# Altium schematic sheet power.SchDoc
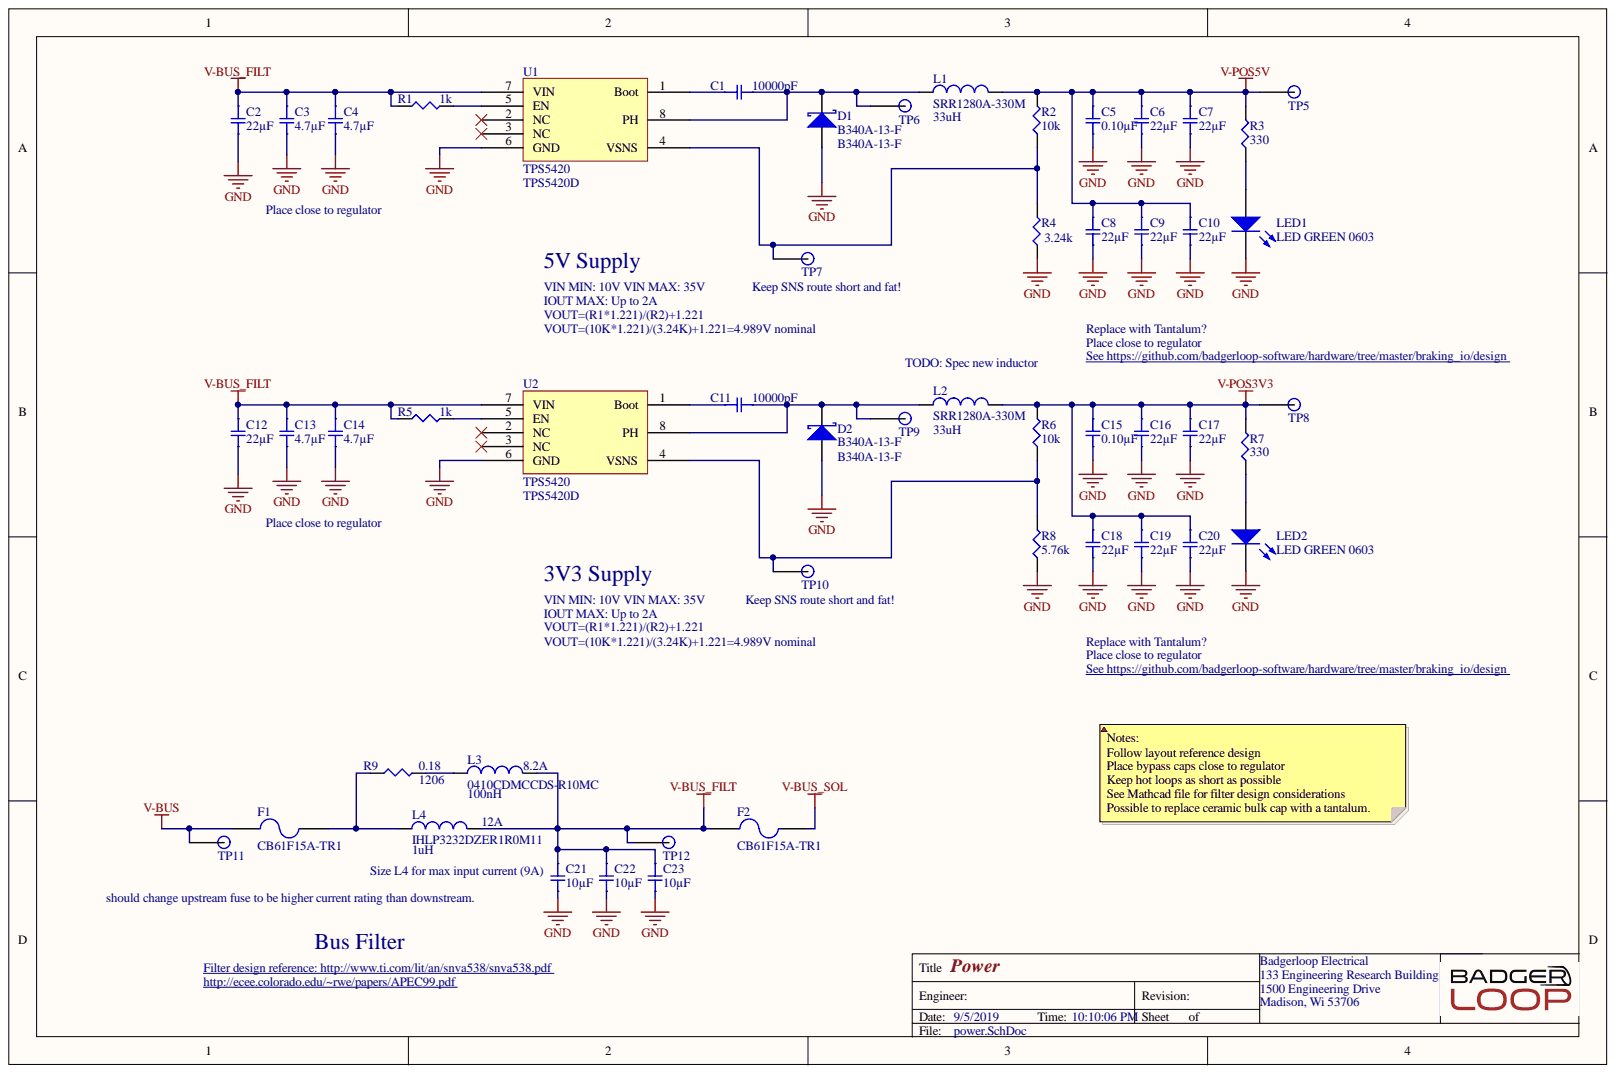
[diagram p0: power.SchDoc]

## Parts
C1  10000pF  [SMD-0805C]
C2  22µF  [SMD-1206C]
C3  4.7µF  [SMD-1206C]
C4  4.7µF  [SMD-1206C]
C5  0.10µF  [SMD-0805C]
C6  22µF  [SMD-1206C]
C7  22µF  [SMD-1206C]
C8  22µF  [SMD-1206C]
C9  22µF  [SMD-1206C]
C10  22µF  [SMD-1206C]
C11  10000pF  [SMD-0805C]
C12  22µF  [SMD-1206C]
C13  4.7µF  [SMD-1206C]
C14  4.7µF  [SMD-1206C]
C15  0.10µF  [SMD-0805C]
C16  22µF  [SMD-1206C]
C17  22µF  [SMD-1206C]
C18  22µF  [SMD-1206C]
C19  22µF  [SMD-1206C]
C20  22µF  [SMD-1206C]
C21  10µF  [SMD-1206C]
C22  10µF  [SMD-1206C]
C23  10µF  [SMD-1206C]
D1  B340A-13-F  [DIOM4326X20N]
D2  B340A-13-F  [DIOM4326X20N]
F1  CB61F15A-TR1  [RESC6132X26N]
F2  CB61F15A-TR1  [RESC6132X26N]
L1  SRR1280A-330M  [INDM120125X75N]
L2  SRR1280A-330M  [INDM120125X75N]
L3  0410CDMCCDS-R10MC  Inductance: 100nH  Saturation Current: 8.2A  [INDM4442X20N]
L4  IHLP3232DZER1R0M11  Inductance: 1uH  Saturation Current: 12A  [INDM8682X40N]
LED1  LED GREEN 0603  [LED 0603 GREEN]
LED2  LED GREEN 0603  [LED 0603 GREEN]
R1  1k  [SMD-0805-RES]
R2  10k  [SMD-0805-RES]
R3  330  [SMD-0805-RES]
R4  3.24k  [SMD-0805-RES]
R5  1k  [SMD-0805-RES]
R6  10k  [SMD-0805-RES]
R7  330  [SMD-0805-RES]
R8  5.76k  [SMD-0805-RES]
R9  0.18  Supplier Device Package: 1206  [SMD-1206-RES]
TP5  TP  [Test Point]
TP6  TP  [Test Point]
TP7  TP  [Test Point]
TP8  TP  [Test Point]
TP9  TP  [Test Point]
TP10  TP  [Test Point]
TP11  TP  [Test Point]
TP12  TP  [Test Point]
U1  TPS5420  Manufacturer Part Number: TPS5420D  [SOP127P600X175-8N]
U2  TPS5420  Manufacturer Part Number: TPS5420D  [SOP127P600X175-8N]

## Nets
GND: C2.2 C3.2 C4.2 C5.2 C6.2 C7.2 C8.2 C9.2 C10.2 C12.2 C13.2 C14.2 C15.2 C16.2 C17.2 C18.2 C19.2 C20.2 C21.2 C22.2 C23.2 D1.1 D2.1 LED1.2 LED2.2 R4.1 R8.1 U1.6(GND) U2.6(GND)
V-BUS: F1.1 TP11.1
V-BUS_FILT: C2.1 C3.1 C4.1 C12.1 C13.1 C14.1 C21.1 C22.1 C23.1 F2.1 L3.2 L4.2 R1.1 R5.1 TP12.1 U1.7(VIN) U2.7(VIN)
V-BUS_SOL: F2.2
V-POS3V3: C15.1 C16.1 C17.1 C18.1 C19.1 C20.1 L2.2 R6.2 R7.2 TP8.1
V-POS5V: C5.1 C6.1 C7.1 C8.1 C9.1 C10.1 L1.2 R2.2 R3.2 TP5.1
NetC1_1: C1.1 U1.1(Boot)
NetC1_2: C1.2 D1.2 L1.1 TP6.1 U1.8(PH)
NetC11_1: C11.1 U2.1(Boot)
NetC11_2: C11.2 D2.2 L2.1 TP9.1 U2.8(PH)
NetF1_2: F1.2 L4.1 R9.1
NetL3_1: L3.1 R9.2
NetLED1_1: LED1.1 R3.1
NetLED2_1: LED2.1 R7.1
NetR1_2: R1.2 U1.5(EN)
NetR2_1: R2.1 R4.2 TP7.1 U1.4(VSNS)
NetR5_2: R5.2 U2.5(EN)
NetR6_1: R6.1 R8.2 TP10.1 U2.4(VSNS)

Not connected: U1 2(NC) 3(NC); U2 2(NC) 3(NC)

## Library data of the parts
C1 C11 = GC321AD72E103KX01D: CAP CER 10000PF 250V X7T 0805; Manufacturer: Murata Electronics North America; @ qty: 0; Minimum Quantity: 1; Tolerance: ±10%; Unit Price (USD): 0.3; Applications: Automotive; Component Kind: Standard; Datasheets: http://search.murata.co.jp/Ceramy/image/img/A01X/G101/ENG/GC321AD72E103KX01-01.pdf; Digi-Key Part Number: 490-13986-1-ND; Factory Stock: 0; Features: -; Height - Seated (Max): -; Image: //media.digikey.com/Renders/Murata%20Renders/0805(GC3)200x125.jpg; Lead Spacing: -; Lead Style: -; Library Name: capacitors.SchLib; Mounting Type: Surface Mount, MLCC; Operating Temperature: -55°C ~ 125°C; Package / Case: 0805 (2012 Metric); Packaging: Cut Tape (CT); Part Number: GC321AD72E103KX01D; Part Status: Active; Quantity Available: 4000; Ratings: AEC-Q200; Series: GC3; Size / Dimension: 0.079" L x 0.049" W (2.00mm x 1.25mm); Temperature Coefficient: X7T; Thickness (Max): 0.039" (1.00mm); Value: 10000pF; Voltage: 250V
C2 C6-C10 C12 C16-C20 = GRT31CR61E226ME01L: CAP CER 22UF 25V X5R 1206; Manufacturer: Murata Electronics North America; @ qty: 0; Minimum Quantity: 1; Tolerance: ±20%; Unit Price (USD): 0.52; Applications: Automotive; Component Kind: Standard; Datasheets: http://search.murata.co.jp/Ceramy/image/img/A01X/G101/ENG/GRT31CR61E226ME01-01.pdf; Digi-Key Part Number: 490-12451-1-ND; Factory Stock: 0; Features: -; Height - Seated (Max): -; Image: //media.digikey.com/Renders/Murata%20Renders/GR331BD72E473KW01L.jpg; Lead Spacing: -; Lead Style: -; Library Name: capacitors.SchLib; Mounting Type: Surface Mount, MLCC; Operating Temperature: -55°C ~ 85°C; Package / Case: 1206 (3216 Metric); Packaging: Cut Tape (CT); Part Number: GRT31CR61E226ME01L; Part Status: Active; Quantity Available: 4719; Ratings: AEC-Q200; Series: GRT; Size / Dimension: 0.126" L x 0.063" W (3.20mm x 1.60mm); Temperature Coefficient: X5R; Thickness (Max): 0.071" (1.80mm); Value: 22µF; Voltage: 25V
C3 C4 C13 C14 = GCM31CC71H475KA03L: CAP CER 4.7UF 50V X7S 1206; Manufacturer: Murata Electronics North America; @ qty: 0; Minimum Quantity: 1; Tolerance: ±10%; Unit Price (USD): 0.57; Applications: Automotive; Component Kind: Standard; Datasheets: http://www.murata.com/~/media/webrenewal/support/library/catalog/products/capacitor/mlcc/c03e.ashx; Digi-Key Part Number: 490-11167-1-ND; Factory Stock: 0; Features: -; Height - Seated (Max): -; Image: //media.digikey.com/Renders/Murata%20Renders/GR331BD72E473KW01L.jpg; Lead Spacing: -; Lead Style: -; Library Name: capacitors.SchLib; Mounting Type: Surface Mount, MLCC; Operating Temperature: -55°C ~ 125°C; Package / Case: 1206 (3216 Metric); Packaging: Cut Tape (CT); Part Number: GCM31CC71H475KA03L; Part Status: Active; Quantity Available: 11700; Ratings: AEC-Q200; Series: GCM; Size / Dimension: 0.126" L x 0.063" W (3.20mm x 1.60mm); Temperature Coefficient: X7S; Thickness (Max): 0.071" (1.80mm); Value: 4.7µF; Voltage: 50V
C5 C15 = GRM21B7U1A104JA01L: CAP CER 0.1UF 10V U2J 0805; Manufacturer: Murata Electronics North America; @ qty: 0; Minimum Quantity: 1; Tolerance: ±5%; Unit Price (USD): 0.4; Applications: General Purpose; Component Kind: Standard; Datasheets: http://www.murata.com/~/media/webrenewal/support/library/catalog/products/capacitor/mlcc/c02e.pdf; Digi-Key Part Number: 490-11592-1-ND; Factory Stock: 0; Features: -; Height - Seated (Max): -; Image: //media.digikey.com/Renders/Murata%20Renders/0805%20(2012%20Metric)%20.85mm.jpg; Lead Spacing: -; Lead Style: -; Library Name: capacitors.SchLib; Mounting Type: Surface Mount, MLCC; Operating Temperature: -55°C ~ 125°C; Package / Case: 0805 (2012 Metric); Packaging: Cut Tape (CT); Part Number: GRM21B7U1A104JA01L; Part Status: Active; Quantity Available: 3390; Ratings: -; Series: GRM; Size / Dimension: 0.079" L x 0.049" W (2.00mm x 1.25mm); Temperature Coefficient: U2J; Thickness (Max): 0.053" (1.35mm); Value: 0.10µF; Voltage: 10V
C21-C23 = GRT31CR61H106ME01L: CAP CER 10UF 50V X5R 1206; Manufacturer: Murata Electronics North America; @ qty: 0; Minimum Quantity: 1; Tolerance: ±20%; Unit Price (USD): 0.42; Applications: Automotive; Component Kind: Standard; Datasheets: http://search.murata.co.jp/Ceramy/image/img/A01X/G101/ENG/GRT31CR61H106ME01-01.pdf; Digi-Key Part Number: 490-12457-1-ND; Factory Stock: 0; Features: -; Height - Seated (Max): -; Image: //media.digikey.com/Renders/Murata%20Renders/GR331BD72E473KW01L.jpg; Lead Spacing: -; Lead Style: -; Library Name: capacitors.SchLib; Mounting Type: Surface Mount, MLCC; Operating Temperature: -55°C ~ 85°C; Package / Case: 1206 (3216 Metric); Packaging: Cut Tape (CT); Part Number: GRT31CR61H106ME01L; Part Status: Active; Quantity Available: 3394; Ratings: AEC-Q200; Series: GRT; Size / Dimension: 0.126" L x 0.063" W (3.20mm x 1.60mm); Temperature Coefficient: X5R; Thickness (Max): 0.071" (1.80mm); Value: 10µF; Voltage: 50V
D1 D2 = B340A-13-F: DIODE SCHOTTKY 40V 3A SMA; Manufacturer: Diodes; Capacitance: 250pF; Element Configuration: Single; Forward Voltage: 500mV; Max Forward Surge Current (Ifsm): 80A; Peak Reverse Current: 500uA; Polarity: Standard; Average Rectified Current: 3A; Case/Package: SMA; Component Kind: Standard; ComponentLink1Description: https://datasheet.ciiva.com/26949/getdatasheetpartid-143394-26949352.pdf?src-supplier=Verical; ComponentLink1URL: https://datasheet.ciiva.com/26949/getdatasheetpartid-143394-26949352.pdf?src-supplier=Verical; ComponentLink2Description: https://datasheet.ciiva.com/4245/861ds30891-4245763.pdf?src-supplier=Arrow; ComponentLink2URL: https://datasheet.ciiva.com/4245/861ds30891-4245763.pdf?src-supplier=Arrow; ComponentLink3Description: https://datasheet.ciiva.com/1177/ds30891-1177476.pdf?src-supplier=Element14; ComponentLink3URL: https://datasheet.ciiva.com/1177/ds30891-1177476.pdf?src-supplier=Element14; ComponentLink4Description: https://datasheet.ciiva.com/913/ds30891-913638.pdf?src-supplier=Digi-Key; ComponentLink4URL: https://datasheet.ciiva.com/913/ds30891-913638.pdf?src-supplier=Digi-Key; ComponentLink5Description: https://datasheet.ciiva.com/46393/1911813-46393773.pdf?src-supplier=Element14; ComponentLink5URL: https://datasheet.ciiva.com/46393/1911813-46393773.pdf?src-supplier=Element14; ComponentLink6Description: https://datasheet.ciiva.com/1240/ds30891-1240228.pdf?src-supplier=Newark; ComponentLink6URL: https://datasheet.ciiva.com/1240/ds30891-1240228.pdf?src-supplier=Newark; ComponentLink7Description: https://datasheet.ciiva.com/913/ds30891-913637.pdf?src-supplier=Digi-Key; ComponentLink7URL: https://datasheet.ciiva.com/913/ds30891-913637.pdf?src-supplier=Digi-Key; ComponentLink8Description: https://datasheet.ciiva.com/913/ds30891-913639.pdf?src-supplier=Digi-Key; ComponentLink8URL: https://datasheet.ciiva.com/913/ds30891-913639.pdf?src-supplier=Digi-Key; Contact Plating: Tin,Matte; Current: 3A; Current Rating: 3A; Forward Current: 3A; Height: 2.3mm; Lead Free: Lead Free; Length: 4.6mm; Library Name: misc.SchLib; Manufacturer Part Number: B340A-13-F; Max Operating Temperature: 125°C; Max Repetitive Reverse Voltage (Vrrm): 40V; Max Reverse Voltage (DC): 40V; Min Operating Temperature: -55°C; Mount: Surface Mount; Number of Pins: 2; Output Current: 3A; Package Quantity: 5000; Packaging: Tape and Reel; Peak Non-Repetitive Surge Current: 80A; Pricing 1: 1=0.42000, 10=0.33900, 100=0.23070, 500=0.17304, 1000=0.12978 (USD); Radiation Hardening: No; REACH SVHC: No SVHC; RoHS: Yes; Stock 1: 438328; Supplier 1: Digi-Key; Supplier Part Number 1: B340A-FDICT-ND; Termination: SMD/SMT; Voltage: 40V; Voltage Rating (DC): 40V; Weight: 0.002258oz; Width: 2.92mm
F1 F2 = CB61F15A-TR1: Manufacturer: Eaton; Approvals: cULus,PSE; Current Rating: 15A; DC Cold Resistance: 4mR; Fuse Type: Board Mount (Cartridge Style Excluded); Voltage Rating: 125V; Case/Package: SMD/SMT; Component Kind: Standard; ComponentLink1Description: http://components.arrow.com/part/dataview/0323_05918082?src-supplier=Arrow+Europe; ComponentLink1URL: http://components.arrow.com/part/dataview/0323_05918082?src-supplier=Arrow+Europe; ComponentLink2Description: http://www.cooperindustries.com/content/dam/public/bussmann/Electronics/Resources/product-datasheets/bus-elx-ds-4377-cb61f.pdf?src-supplier=Avnet+Asia; ComponentLink2URL: http://www.cooperindustries.com/content/dam/public/bussmann/Electronics/Resources/product-datasheets/bus-elx-ds-4377-cb61f.pdf?src-supplier=Avnet+Asia; ComponentLink3Description: http://www.cooperindustries.com/content/dam/public/bussmann/Electronics/Resources/product-datasheets/bus-elx-ds-4377-cb61f.pdf?src-supplier=Avnet; ComponentLink3URL: http://www.cooperindustries.com/content/dam/public/bussmann/Electronics/Resources/product-datasheets/bus-elx-ds-4377-cb61f.pdf?src-supplier=Avnet; ComponentLink4Description: http://www.cooperindustries.com/content/dam/public/bussmann/Electronics/Resources/product-datasheets/bus-elx-ds-4377-cb61f.pdf?src-supplier=Digi-Key; ComponentLink4URL: http://www.cooperindustries.com/content/dam/public/bussmann/Electronics/Resources/product-datasheets/bus-elx-ds-4377-cb61f.pdf?src-supplier=Digi-Key; Depth: 2.57mm; Height: 0.101inch; Lead Free: Lead Free; Length: 0.24inch; Library Name: misc.SchLib; Manufacturer Part Number: CB61F15A-TR1; Mount: Surface Mount; Packaging: Tape and Reel; Pricing 1: 1=1.15000, 5=1.11800, 10=1.04600, 50=0.86600, 100=0.72160, 250=0.70356, 500=0.59532 (USD); RoHS: Yes; Stock 1: 11809; Supplier 1: Digi-Key; Supplier Part Number 1: 283-4104-1-ND; Voltage Rating (AC): 125V; Voltage Rating (DC): 125V; Width: 0.101inch
L1 L2 = SRR1280A-330M: Inductor Power Shielded Wirewound 33uH 20% 1KHz 28Q-Factor Ferrite 3.5A 57mOhm DCR Automotive T/R; Manufacturer: Bourns; DC Resistance (DCR): 57mR; Saturation Current: 3.3A; Self Resonant Frequency: 9.5MHz; Case/Package: Nonstandard; Component Kind: Standard; ComponentLink1Description: https://datasheet.ciiva.com/41859/srr1280a-41859670.pdf?src-supplier=Digi-Key; ComponentLink1URL: https://datasheet.ciiva.com/41859/srr1280a-41859670.pdf?src-supplier=Digi-Key; ComponentLink2Description: https://datasheet.ciiva.com/48684/srr1280a-48684991.pdf?src-supplier=Avnet; ComponentLink2URL: https://datasheet.ciiva.com/48684/srr1280a-48684991.pdf?src-supplier=Avnet; Core Material: Ferrite; Current Rating: 3.5A; Depth: 12.8mm; Height: 7.5mm; Height - Seated (Max): 0.315inch; Inductance: 33uH; Inductance Tolerance: 20%; Length: 0.492inch; Library Name: misc.SchLib; Manufacturer Part Number: SRR1280A-330M; Max DC Current: 3.5A; Max Operating Temperature: 125°C; Military Standard: Not; Min Operating Temperature: -40°C; Mount: Surface Mount; Package Quantity: 400; Packaging: Cut Tape; Pricing 1: 2400=0.54000, 4000=0.48600, 6000=0.46800, 10000=0.45800 (USD); Ratings: AEC-Q200; REACH SVHC: No SVHC; RMS Current (Irms): 3.5A; RoHS: Yes; Shielding: Shielded; Stock 1: 0; Supplier 1: Newark; Supplier Part Number 1: 06X7909; Test Frequency: 1kHz; Tolerance: 20%; Width: 0.492inch
L3 = 0410CDMCCDS-R10MC: Manufacturer: Sumida; Component Kind: Standard; Library Name: inductor.SchLib; Manufacturer Part Number: 0410CDMCCDS-R10MC; Pricing 1: 1=0.93000, 10=0.81700, 100=0.58360, 500=0.49024, 1000=0.39687 (USD); RoHS: No; Stock 1: 5994; Supplier 1: Digi-Key; Supplier Part Number 1: 308-2523-1-ND
L4 = IHLP3232DZER1R0M11: Fixed Inductors 1uH 20% Low Profile; Manufacturer: Vishay Dale; Core Material: Metal,Composite,Ferrite; Current Rating: 18.2A; DC Resistance (DCR): 4.63mR; Self Resonant Frequency: 49MHz; Shielding: Shielded; Test Frequency: 100kHz; Case/Package: 3232; Component Kind: Standard; ComponentLink1Description: https://datasheet.ciiva.com/6692/ihlp-3232dz-11-6692199.pdf?src-supplier=Digi-Key; ComponentLink1URL: https://datasheet.ciiva.com/6692/ihlp-3232dz-11-6692199.pdf?src-supplier=Digi-Key; ComponentLink2Description: https://datasheet.ciiva.com/6692/ihlp-3232dz-11-6692200.pdf?src-supplier=Digi-Key; ComponentLink2URL: https://datasheet.ciiva.com/6692/ihlp-3232dz-11-6692200.pdf?src-supplier=Digi-Key; ComponentLink3Description: https://datasheet.ciiva.com/6692/ihlp-3232dz-11-6692201.pdf?src-supplier=Digi-Key; ComponentLink3URL: https://datasheet.ciiva.com/6692/ihlp-3232dz-11-6692201.pdf?src-supplier=Digi-Key; ComponentLink4Description: https://datasheet.ciiva.com/17113/70231623-17113262.pdf?src-supplier=Allied+Electronics; ComponentLink4URL: https://datasheet.ciiva.com/17113/70231623-17113262.pdf?src-supplier=Allied+Electronics; Depth: 8.94mm; Height: 4mm; Height - Seated (Max): 0.157inch; Inductance Tolerance: 20%; Lead Free: Lead Free; Length: 8.64mm; Library Name: misc.SchLib; Manufacturer Part Number: IHLP3232DZER1R0M11; Max DC Current: 18.2A; Max Operating Temperature: 125°C; Military Standard: Not; Min Operating Temperature: -55°C; Mount: Surface Mount; Number of Pins: 2; Package Quantity: 500; Packaging: Tape and Reel; Pricing 1: 1=1.61000, 10=1.49500, 100=1.08100 (USD); Radiation Hardening: No; REACH SVHC: Unknown; RMS Current (Irms): 18.2A; RoHS: Yes; Stock 1: 9463; Supplier 1: Digi-Key; Supplier Part Number 1: 541-1348-1-ND; Termination: SMD/SMT; Tolerance: 20%; Width: 0.322inch
LED1 LED2 = LED GREEN 0603: Manufacturer: Lite-On Inc.; Manufacturer P/N: LTST-C190KGKT; Color: GREEN; Component Kind: Standard; Digikey P/N: 160-1435-1-ND; Library Name: misc.SchLib; Package / Case: 0603
R1 R5 = ERJ-P06J102V: RES SMD 1K OHM 5% 1/2W 0805; Manufacturer: Panasonic Electronic Components; @ qty: 1500; Factory Stock: 0; Minimum Quantity: 1; Power (Watts): 0.5W, 1/2W; Temperature Coefficient: ±200ppm/°C; Unit Price (USD): 0.01839; Component Kind: Standard; Composition: Thick Film; Datasheets: https://industrial.panasonic.com/cdbs/www-data/pdf/RDA0000/AOA0000C243.pdf; Digi-Key Part Number: P1.0KADCT-ND; Failure Rate: -; Features: Automotive AEC-Q200, Pulse Withstanding; Height - Seated (Max): 0.028" (0.70mm); Image: //media.digikey.com/Renders/Panasonic%20Renders/ERJ-0805%20Pkg.jpg; Library Name: resistors.SchLib; Number of Terminations: 2; Operating Temperature: -55°C ~ 155°C; Package / Case: 0805 (2012 Metric); Packaging: Cut Tape (CT); Part Number: ERJ-P06J102V; Part Status: Active; Quantity Available: 124757; Series: ERJ; Size / Dimension: 0.079" L x 0.049" W (2.00mm x 1.25mm); Supplier Device Package: 0805; Tolerance: ±5%; Value: 1k
R2 R6 = ERJ-P06J103V: RES SMD 10K OHM 5% 1/2W 0805; Manufacturer: Panasonic Electronic Components; @ qty: 1500; Factory Stock: 0; Minimum Quantity: 1; Power (Watts): 0.5W, 1/2W; Temperature Coefficient: ±200ppm/°C; Unit Price (USD): 0.01839; Component Kind: Standard; Composition: Thick Film; Datasheets: https://industrial.panasonic.com/cdbs/www-data/pdf/RDA0000/AOA0000C243.pdf; Digi-Key Part Number: P10KADCT-ND; Failure Rate: -; Features: Automotive AEC-Q200, Pulse Withstanding; Height - Seated (Max): 0.028" (0.70mm); Image: //media.digikey.com/Renders/Panasonic%20Renders/ERJ-0805%20Pkg.jpg; Library Name: resistors.SchLib; Number of Terminations: 2; Operating Temperature: -55°C ~ 155°C; Package / Case: 0805 (2012 Metric); Packaging: Cut Tape (CT); Part Number: ERJ-P06J103V; Part Status: Active; Quantity Available: 413576; Series: ERJ; Size / Dimension: 0.079" L x 0.049" W (2.00mm x 1.25mm); Supplier Device Package: 0805; Tolerance: ±5%; Value: 10k
R3 R7 = ERJ-P06J331V: RES SMD 330 OHM 5% 1/2W 0805; Manufacturer: Panasonic Electronic Components; @ qty: 1500; Factory Stock: 0; Minimum Quantity: 1; Power (Watts): 0.5W, 1/2W; Temperature Coefficient: ±200ppm/°C; Unit Price (USD): 0.01839; Component Kind: Standard; Composition: Thick Film; Datasheets: https://industrial.panasonic.com/cdbs/www-data/pdf/RDA0000/AOA0000C243.pdf; Digi-Key Part Number: P330ADCT-ND; Failure Rate: -; Features: Automotive AEC-Q200, Pulse Withstanding; Height - Seated (Max): 0.028" (0.70mm); Image: //media.digikey.com/Renders/Panasonic%20Renders/ERJ-0805%20Pkg.jpg; Library Name: resistors.SchLib; Number of Terminations: 2; Operating Temperature: -55°C ~ 155°C; Package / Case: 0805 (2012 Metric); Packaging: Cut Tape (CT); Part Number: ERJ-P06J331V; Part Status: Active; Quantity Available: 74125; Series: ERJ; Size / Dimension: 0.079" L x 0.049" W (2.00mm x 1.25mm); Supplier Device Package: 0805; Tolerance: ±5%; Value: 330
R4 = ERJ-6ENF3241V: RES SMD 3.24K OHM 1% 1/8W 0805; Manufacturer: Panasonic Electronic Components; @ qty: 1500; Factory Stock: 0; Minimum Quantity: 1; Power (Watts): 0.125W, 1/8W; Temperature Coefficient: ±100ppm/°C; Unit Price (USD): 0.00729; Component Kind: Standard; Composition: Thick Film; Datasheets: http://industrial.panasonic.com/www-cgi/jvcr13pz.cgi?E+PZ+3+AOA0002+ERJ6ENF3241V+7+WW; Digi-Key Part Number: P3.24KCCT-ND; Failure Rate: -; Features: Automotive AEC-Q200; Height - Seated (Max): 0.028" (0.70mm); Image: //media.digikey.com/Renders/Panasonic%20Renders/ERJ-0805%20Pkg.jpg; Library Name: resistors.SchLib; Number of Terminations: 2; Operating Temperature: -55°C ~ 155°C; Package / Case: 0805 (2012 Metric); Packaging: Cut Tape (CT); Part Number: ERJ-6ENF3241V; Part Status: Active; Quantity Available: 47619; Series: ERJ; Size / Dimension: 0.079" L x 0.049" W (2.00mm x 1.25mm); Supplier Device Package: 0805; Tolerance: ±1%; Value: 3.24k
R8 = ERJ-6ENF5761V: RES SMD 5.76K OHM 1% 1/8W 0805; Manufacturer: Panasonic Electronic Components; @ qty: 1500; Factory Stock: 0; Minimum Quantity: 1; Power (Watts): 0.125W, 1/8W; Temperature Coefficient: ±100ppm/°C; Unit Price (USD): 0.00729; Component Kind: Standard; Composition: Thick Film; Datasheets: http://industrial.panasonic.com/www-cgi/jvcr13pz.cgi?E+PZ+3+AOA0002+ERJ6ENF5761V+7+WW; Digi-Key Part Number: P5.76KCCT-ND; Failure Rate: -; Features: Automotive AEC-Q200; Height - Seated (Max): 0.028" (0.70mm); Image: //media.digikey.com/Renders/Panasonic%20Renders/ERJ-0805%20Pkg.jpg; Library Name: resistors.SchLib; Number of Terminations: 2; Operating Temperature: -55°C ~ 155°C; Package / Case: 0805 (2012 Metric); Packaging: Cut Tape (CT); Part Number: ERJ-6ENF5761V; Part Status: Active; Quantity Available: 40014; Series: ERJ; Size / Dimension: 0.079" L x 0.049" W (2.00mm x 1.25mm); Supplier Device Package: 0805; Tolerance: ±1%; Value: 5.76k
R9 = ERJ-8BSFR18V: RES SMD 0.18 OHM 1% 1/2W 1206; Manufacturer: Panasonic Electronic Components; @ qty: 1500; Factory Stock: 0; Minimum Quantity: 1; Power (Watts): 0.5W, 1/2W; Temperature Coefficient: ±250ppm/°C; Unit Price (USD): 0.11739; Component Kind: Standard; Composition: Thick Film; Datasheets: https://industrial.panasonic.com/cdbs/www-data/pdf/RDA0000/AOA0000C239.pdf; Digi-Key Part Number: P17683CT-ND; Failure Rate: -; Features: Automotive AEC-Q200, Current Sense; Height - Seated (Max): 0.028" (0.70mm); Image: //media.digikey.com/Renders/Panasonic%20Renders/ERJ-1206%20Pkg.jpg; Library Name: resistors.SchLib; Number of Terminations: 2; Operating Temperature: -55°C ~ 125°C; Package / Case: 1206 (3216 Metric); Packaging: Cut Tape (CT); Part Number: ERJ-8BSFR18V; Part Status: Active; Quantity Available: 1772; Series: ERJ; Size / Dimension: 0.126" L x 0.063" W (3.20mm x 1.60mm); Tolerance: ±1%; Value: 0.18
TP5-TP12 = TP: SMD TEST POINT; Component Kind: Standard; Library Name: misc.SchLib
U1 U2 = TPS5420: Manufacturer: Texas Instruments; Efficiency: 95%; Max Input Voltage: 36V; Max Output Current: 2A; Max Output Voltage: 31V; Min Input Voltage: 5.5V; Min Output Voltage: 1.23V; Number of Outputs: 1; Output Type: Adjustable; Quiescent Current: 3mA; Switching Frequency: 500kHz; Synchronous Rectifier: No; Case/Package: D; Component Kind: Standard; ComponentLink1Description: https://datasheet.ciiva.com/4880/slvs642c-120503-4880259.pdf?src-supplier=Mouser; ComponentLink1URL: https://datasheet.ciiva.com/4880/slvs642c-120503-4880259.pdf?src-supplier=Mouser; ComponentLink2Description: https://datasheet.ciiva.com/15113/getdatasheetpartid-188336-15113748.pdf?src-supplier=Verical; ComponentLink2URL: https://datasheet.ciiva.com/15113/getdatasheetpartid-188336-15113748.pdf?src-supplier=Verical; ComponentLink3Description: https://datasheet.ciiva.com/27051/1113040-27051457.pdf?src-supplier=Jameco; ComponentLink3URL: https://datasheet.ciiva.com/27051/1113040-27051457.pdf?src-supplier=Jameco; ComponentLink4Description: https://datasheet.ciiva.com/26896/tps5420d-26896666.pdf?src-supplier=IIIC; ComponentLink4URL: https://datasheet.ciiva.com/26896/tps5420d-26896666.pdf?src-supplier=IIIC; ComponentLink5Description: https://datasheet.ciiva.com/2026/tps5420-2026757.pdf?src-supplier=Digi-Key; ComponentLink5URL: https://datasheet.ciiva.com/2026/tps5420-2026757.pdf?src-supplier=Digi-Key; ComponentLink6Description: https://datasheet.ciiva.com/7993/1764415-7993820.pdf?src-supplier=Element14; ComponentLink6URL: https://datasheet.ciiva.com/7993/1764415-7993820.pdf?src-supplier=Element14; ComponentLink7Description: https://datasheet.ciiva.com/3220/tps5420-3220182.pdf?src-supplier=Avnet; ComponentLink7URL: https://datasheet.ciiva.com/3220/tps5420-3220182.pdf?src-supplier=Avnet; ComponentLink8Description: https://datasheet.ciiva.com/26248/tps5420-26248554.pdf?src-supplier=Avnet+Europe; ComponentLink8URL: https://datasheet.ciiva.com/26248/tps5420-26248554.pdf?src-supplier=Avnet+Europe; Height: 1.58mm; Lead Free: Lead Free; Length: 4.9mm; Library Name: ic.SchLib; Max Operating Temperature: 125°C; Min Operating Temperature: -40°C; Number of Pins: 8; Operating Supply Voltage: 36V; Output Current: 2A; Output Voltage: 31V; Package Quantity: 75; Packaging: Rail/Tube; Pricing 1: 1=3.78000, 10=3.39400, 75=3.20853, 150=2.78073, 525=2.36716, 1050=1.99640, 2550=1.89658, 5025=1.82528 (USD); Radiation Hardening: No; REACH SVHC: No SVHC; RoHS: Yes; Stock 1: 5221; Supplier 1: Digi-Key; Supplier Part Number 1: 296-19671-5-ND; Weight: 0.002677oz; Width: 3.91mm
